# Altium schematic sheet USB.SchDoc
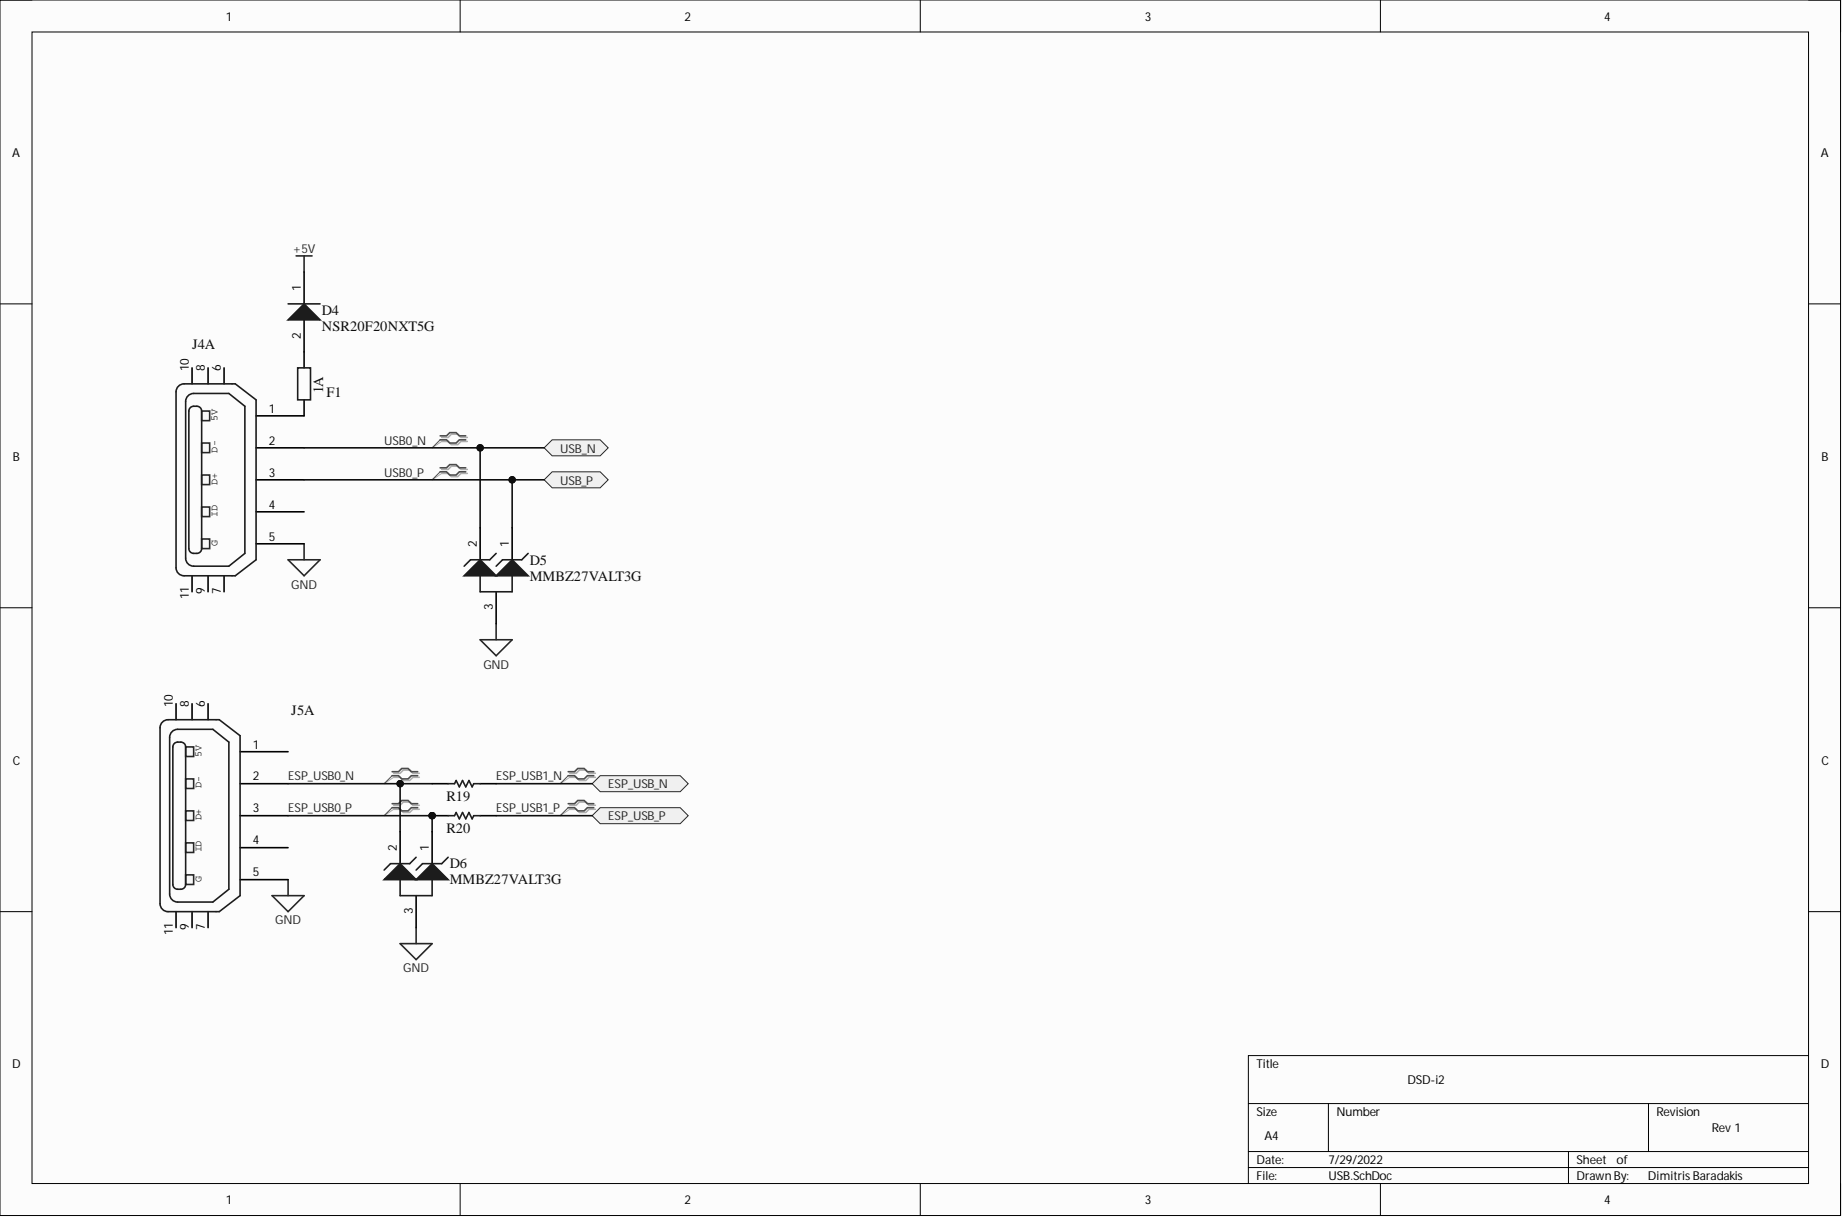
[diagram p0: USB.SchDoc]

## Parts
D4  NSR20F20NXT5G  [ONSC-DSN-2-512AB-01_V]
D5  MMBZ27VALT3G  [ONSC-SOT-23-3-318-08_V]
D6  MMBZ27VALT3G  [ONSC-SOT-23-3-318-08_V]
F1  Bel Fuse  [PCB-vwv6ix814uad1yajp7ls-1]  (value not drawn)
J4  Amphenol ICC / FCI 10118194-0001LF  [PCB-xkovqtr4ibyzrydzae7s-1]  (value not drawn)  (part A)
J5  Amphenol ICC / FCI 10118194-0001LF  [PCB-xkovqtr4ibyzrydzae7s-1]  (value not drawn)  (part A)
R19  Bourns CR0201AJ/-000GLF  [Bourns CR0201AJ_-000GLF-Footprint-1]  (value not drawn)
R20  Bourns CR0201AJ/-000GLF  [Bourns CR0201AJ_-000GLF-Footprint-1]  (value not drawn)

## Ports
ESP_USB_N, ESP_USB_P, USB_N, USB_P

## Nets
+5V: D4.1
GND: D5.3 D6.3 J4.5 J5.5
ESP_USB0_N: D6.2 J5.2 R19.1
ESP_USB0_P: D6.1 J5.3 R20.1
ESP_USB1_N: R19.2 ; port ESP_USB_N
ESP_USB1_P: R20.2 ; port ESP_USB_P
USB0_N: D5.2 J4.2 ; port USB_N
USB0_P: D5.1 J4.3 ; port USB_P
NetD4_2: D4.2 F1.2
NetF1_1: F1.1 J4.1

Not connected: J4 4 6 7 8 9 10 11; J5 1 4 6 7 8 9 10 11

## Library data of the parts
D4 = CMP-816722-2: Schottky Barrier Diode, 2-Pin DSN, Pb-Free, Tape and Reel; Lead-Free Status: Lead Free; Component Kind: Standard; Library Name: My Personal Space; Lifecycle Status: Active; Manufacturer Part Number: NSR20F20NXT5G; Octopart Url: https://octopart.com/nsr20f20nxt5g-on+semiconductor-18688324; OctopartID: 647fac68685dbbb8; RoHS: Compliant
D5 D6 = CMP-296094-3: 40 W Peak Power Zener Transient Voltage Suppressor, Dual Common Anode Zeners for ESD Protection, 3-Pin SOT-23, Pb-Free, Tape and Reel; Lead-Free Status: Lead Free; Component Kind: Standard; Library Name: My Personal Space; Lifecycle Status: Active; Manufacturer Part Number: MMBZ27VALT3G; Number of Pins: 2; Octopart Url: https://octopart.com/mmbz27valt3g-on+semiconductor-7139105; OctopartID: 4ec587a384829102; Packaging: Reel; RoHS: Compliant
F1 = CMP-10455596-3: PTC Resettable Fuse 0.5A(hold) 1A(trip) 8VDC 100A 0.4W 0.1s 0.15Ohm SMD Solder Pad 3.5 X 1.8 X 0.55mm T/R; Manufacturer Part Number: 0ZCJ0050FF2G; Lead-Free Status: Lead Free; Component Kind: Standard; Library Name: My Personal Space; Octopart URL: http://octopart.com/0zcj0050ff2g-bel+fuse-29404517; OctopartID: 403488fec8379ff3; RoHS: Compliant
J4 J5 = CMP-b7d77f99eeaa6810-28: FCI Right Angle Surface Mount Mount Female USB Connector; 100 V ac; 1.8A; Lead-Free Status: Lead Free; Color: Black; Component Kind: Standard; Contact Material: Copper Alloy; Contact Plating: Gold; Gender: Female; Library Name: My Personal Space; Manufacturer Part Number: 10118194-0001LF; Mounting Style: Surface Mount; Octopart URL: https://gr.mouser.com/ProductDetail/Amphenol-FCI/10118194-0001LF?qs=Ywefl8B65e4FIdY8OWfRQA%3D%3D; OctopartID: 3c80f0e8467fe347; Orientation: Right Angle; Packaging: Reel; RoHS: Compliant
R19 R20 = CMP-8a1c4579b17155db-3: Thick Film Chip Resistor; 0201 0R Jumper 50mW; Component Kind: Standard; Library Name: My Personal Space; Manufacturer Part Number: CR0201AJ/-000GLF; Octopart Url: https://octopart.com/cr0201aj%2F-000glf-bourns-107945483; OctopartID: 8a1c4579b17155db
